# Altium schematic sheet IntegroDiferencial_SCH.SchDoc
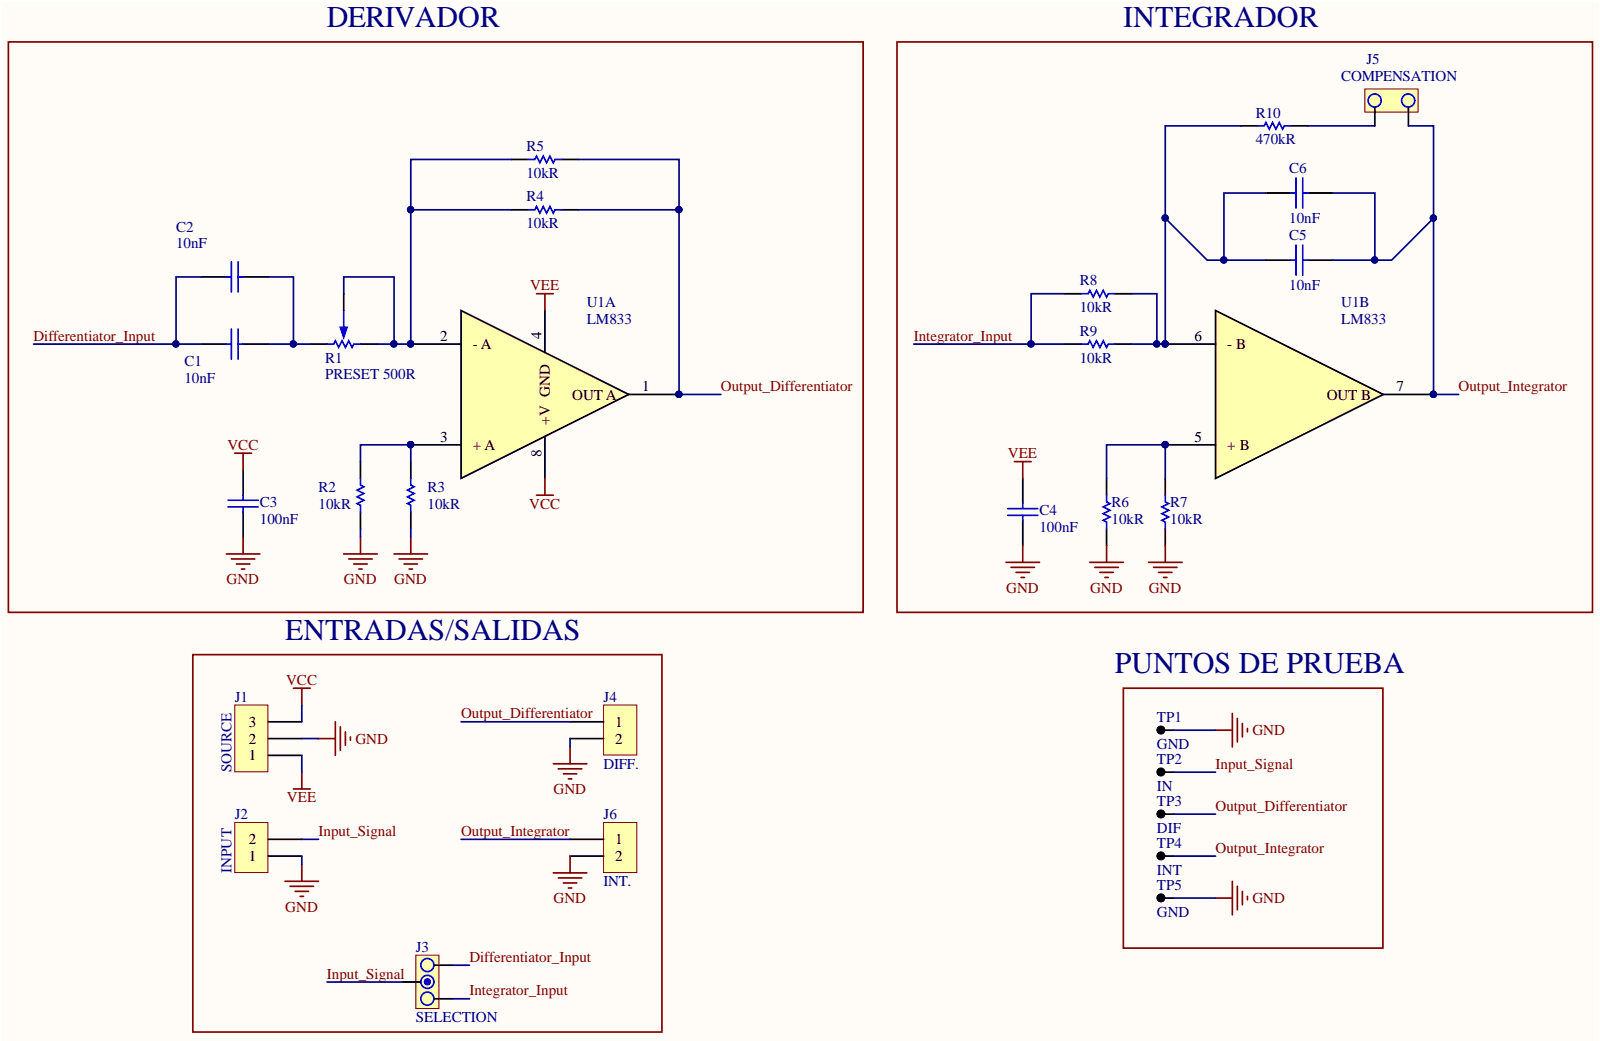
[diagram p0: IntegroDiferencial_SCH.SchDoc]

## Parts
C1  10nF  [0805]
C2  10nF  [0805]
C3  100nF  [0805]
C4  100nF  [0805]
C5  10nF  [0805]
C6  10nF  [0805]
J1  SOURCE  [BORNERA3]
J2  INPUT  [BORNERA2]
J3  SELECTION  [JUMPER3]
J4  DIFF.  [BORNERA2]
J5  COMPENSATION  [JUMPER2]
J6  INT.  [BORNERA2]
R1  PRESET 500R  [PRESET V]
R2  10kR  [0805]
R3  10kR  [0805]
R4  10kR  [0805]
R5  10kR  [0805]
R6  10kR  [0805]
R7  10kR  [0805]
R8  10kR  [0805]
R9  10kR  [0805]
R10  470kR  [0805]
TP1  GND  [TPTH]
TP2  IN  [TPTH]
TP3  DIF  [TPTH]
TP4  INT  [TPTH]
TP5  GND  [TPTH]
U1  LM833  [DIP8]  (parts A,B)

## Nets
GND: C3.1 C4.1 J1.2 J2.1 J4.2 J6.2 R2.1 R3.1 R6.1 R7.1 TP1.1 TP5.1
VCC: C3.2 J1.3 U1.8(+V)
VEE: C4.2 J1.1 U1.4(GND)
DIFFERENTIATOR_INPUT: C1.1 C2.1 J3.1
INPUT_SIGNAL: J2.2 J3.2 TP2.1
INTEGRATOR_INPUT: J3.3 R8.1 R9.1
OUTPUT_DIFFERENTIATOR: J4.1 R4.1 R5.1 TP3.1 U1.1(OUT_A)
OUTPUT_INTEGRATOR: C5.1 C6.1 J5.1 J6.1 TP4.1 U1.7(OUT_B)
NetC1_2: C1.2 C2.2 R1.1
NetC5_2: C5.2 C6.2 R8.2 R9.2 R10.1 U1.6(-_B)
NetJ5_2: J5.2 R10.2
NetR1_2: R1.2 R1.3 R4.2 R5.2 U1.2(-_A)
NetR2_2: R2.2 R3.2 U1.3(+_A)
NetR6_2: R6.2 R7.2 U1.5(+_B)

## Library data of the parts
C1 C2 C5 C6 = CAP: CAP 10nF 0805; Component Kind: Standard; Library Name: LIBEBAL.IntLib
C3 C4 = CAP: CAP 100nF 0805; Component Kind: Standard; Library Name: LIBEBAL.IntLib
J1 = BORNERA 3: Component Kind: Standard; Description: BORNERA 3; Library Name: LIBEBAL.IntLib
J2 J4 J6 = BORNERA 2: Component Kind: Standard; Description: BORNERA 2; Library Name: LIBEBAL.IntLib
J3 = JUMPER3: JUMPER; Component Kind: Standard; Library Name: LIBEBAL.IntLib
J5 = JUMPER: Component Kind: Standard; Description: JUMPER; Library Name: LIBEBAL.IntLib
R1 = PRESET: Component Kind: Standard; Description: PRESET 500R; Library Name: LIBEBAL.IntLib
R2-R9 = RES: RES 10kR 0805; Component Kind: Standard; Library Name: LIBEBAL.IntLib
R10 = RES: RES 470kR 0805; Component Kind: Standard; Library Name: LIBEBAL.IntLib
TP1-TP5 = TP: TESTPOINT; Component Kind: Standard; Library Name: LIBEBAL.IntLib
U1 = LM833: Dual Audio Operational Amplifier; Component Kind: Standard; Library Name: LIBEBAL.IntLib
